# Altium schematic sheet Sheet3.SchDoc(Sheet3)
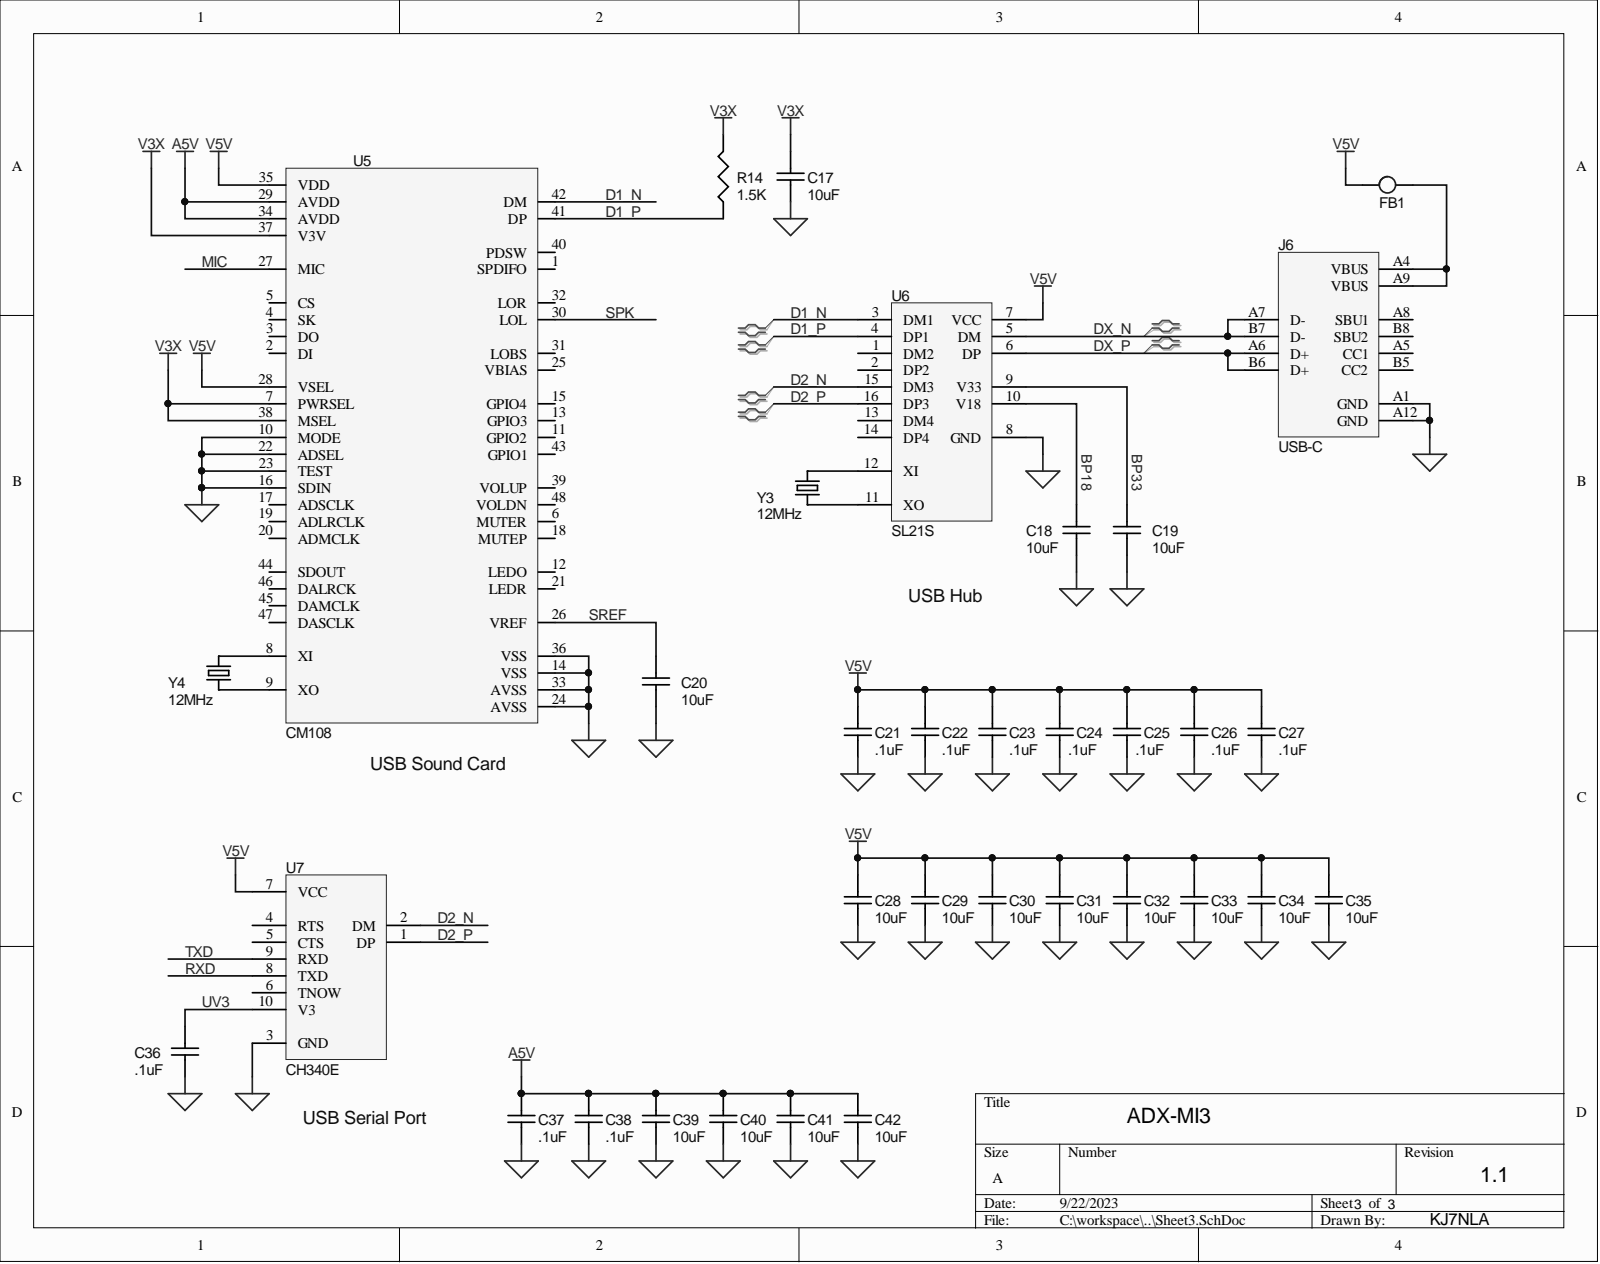
[diagram p0: Sheet3.SchDoc]

## Parts
C17  10uF  [3-0603]
C18  10uF  [3-0603]
C19  10uF  [3-0603]
C20  10uF  [3-0603]
C21  .1uF  [3-0603]
C22  .1uF  [3-0603]
C23  .1uF  [3-0603]
C24  .1uF  [3-0603]
C25  .1uF  [3-0603]
C26  .1uF  [3-0603]
C27  .1uF  [3-0603]
C28  10uF  [3-0603]
C29  10uF  [3-0603]
C30  10uF  [3-0603]
C31  10uF  [3-0603]
C32  10uF  [3-0603]
C33  10uF  [3-0603]
C34  10uF  [3-0603]
C35  10uF  [3-0603]
C36  .1uF  [3-0603]
C37  .1uF  [3-0603]
C38  .1uF  [3-0603]
C39  10uF  [3-0603]
C40  10uF  [3-0603]
C41  10uF  [3-0603]
C42  10uF  [3-0603]
FB1  ferrite bead  [8-1206]  (value not drawn)
J6  USB-C  [USB-C]
R14  1.5K  [3-0603]
U5  CM108  [LQFP48]
U6  SL21S  [SSOP16-2]
U7  CH340E  [MSOP-10]
Y3  12MHz  [Xtal_SMT]
Y4  12MHz  [Xtal_SMT]

## Nets
A5V: C37.2 C38.2 C39.2 C40.2 C41.2 C42.2 U5.29(AVDD) U5.34(AVDD)
GND: C17.1 C18.1 C19.1 C20.1 C21.1 C22.1 C23.1 C24.1 C25.1 C26.1 C27.1 C28.1 C29.1 C30.1 C31.1 C32.1 C33.1 C34.1 C35.1 C36.1 C37.1 C38.1 C39.1 C40.1 C41.1 C42.1 J6.A1(GND) J6.A12(GND) U5.10(MODE) U5.14(VSS) U5.16(SDIN) U5.22(ADSEL) U5.23(TEST) U5.24(AVSS) U5.33(AVSS) U5.36(VSS) U6.8(GND) U7.3(GND)
V3X: C17.2 R14.2 U5.7(PWRSEL) U5.37(V3V) U5.38(MSEL)
V5V: C21.2 C22.2 C23.2 C24.2 C25.2 C26.2 C27.2 C28.2 C29.2 C30.2 C31.2 C32.2 C33.2 C34.2 C35.2 FB1.1 U5.28(VSEL) U5.35(VDD) U6.7(VCC) U7.7(VCC)
BP18: C18.2 U6.10(V18)
BP33: C19.2 U6.9(V33)
D1_N: U5.42(DM) U6.3(DM1)
D1_P: R14.1 U5.41(DP) U6.4(DP1)
D2_N: U6.15(DM3) U7.2(DM)
D2_P: U6.16(DP3) U7.1(DP)
DX_N: J6.A7(D-) J6.B7(D-) U6.5(DM)
DX_P: J6.A6(D+) J6.B6(D+) U6.6(DP)
MIC: U5.27(MIC)
RXD: U7.8(TXD)
SPK: U5.30(LOL)
SREF: C20.2 U5.26(VREF)
TXD: U7.9(RXD)
UV3: C36.2 U7.10(V3)
NetFB1_2: FB1.2 J6.A4(VBUS) J6.A9(VBUS)
NetU5_8: U5.8(XI) Y4.3
NetU5_9: U5.9(XO) Y4.1
NetU6_11: U6.11(XO) Y3.1
NetU6_12: U6.12(XI) Y3.3

Not connected: J6 A5(CC1) A8(SBU1) B5(CC2) B8(SBU2); U5 1(SPDIFO) 2(DI) 3(DO) 4(SK) 5(CS) 6(MUTER) 11(GPIO2) 12(LEDO) 13(GPIO3) 15(GPIO4) 17(ADSCLK) 18(MUTEP) 19(ADLRCLK) 20(ADMCLK) 21(LEDR) 25(VBIAS) 31(LOBS) 32(LOR) 39(VOLUP) 40(PDSW) 43(GPIO1) 44(SDOUT) 45(DAMCLK) 46(DALRCK) 47(DASCLK) 48(VOLDN); U6 1(DM2) 2(DP2) 13(DM4) 14(DP4); U7 4(RTS) 5(CTS) 6(TNOW)

## Library data of the parts
C17-C42 = Cap: Supplier Part Number 1: NA; Supplier 1: Digi-Key; Component Kind: Standard; Library Name: usdx.SchLib
  C17-C20 C28-C35 C39-C42: Value: 10uF; JLCC Part Number: C344022
  C21-C27 C36-C38: Value: .1uF; JLCC Part Number: C113803
FB1 = ferrite: Supplier Part Number 1: NA; Supplier 1: Digi-Key; JLCC Part Number: C130273; Component Kind: Standard; Library Name: usdx.SchLib
R14 = Resistor: Value: 1.5K; Supplier Part Number 1: NA; Supplier 1: Digi-Key; JLCC Part Number: C22843; Component Kind: Standard; Library Name: usdx.SchLib
Y3 Y4 = XTAL-SMT: Value: 12MHz; Supplier Part Number 1: NA; Supplier 1: Digi-Key; JLCC Part Number: C9002; Component Kind: Standard; Library Name: usdx.SchLib
J6 = USB-C: Supplier Part Number 1: NA; Supplier 1: Digi-Key; JLCC Part Number: C2765186; Component Kind: Standard; Library Name: usdx.SchLib
U5 = CM108: Supplier Part Number 1: NA; Supplier 1: Digi-Key; JLCC Part Number: C371347; Component Kind: Standard; Library Name: usdx.SchLib
U6 = SL21S: Supplier Part Number 1: NA; Supplier 1: Digi-Key; JLCC Part Number: C2684433; Component Kind: Standard; Library Name: usdx.SchLib
U7 = CH340E: Supplier Part Number 1: NA; Supplier 1: Digi-Key; JLCC Part Number: C99652; Component Kind: Standard; Library Name: usdx.SchLib
